# Altium schematic sheet ADC_Top Sheet V2.SchDoc
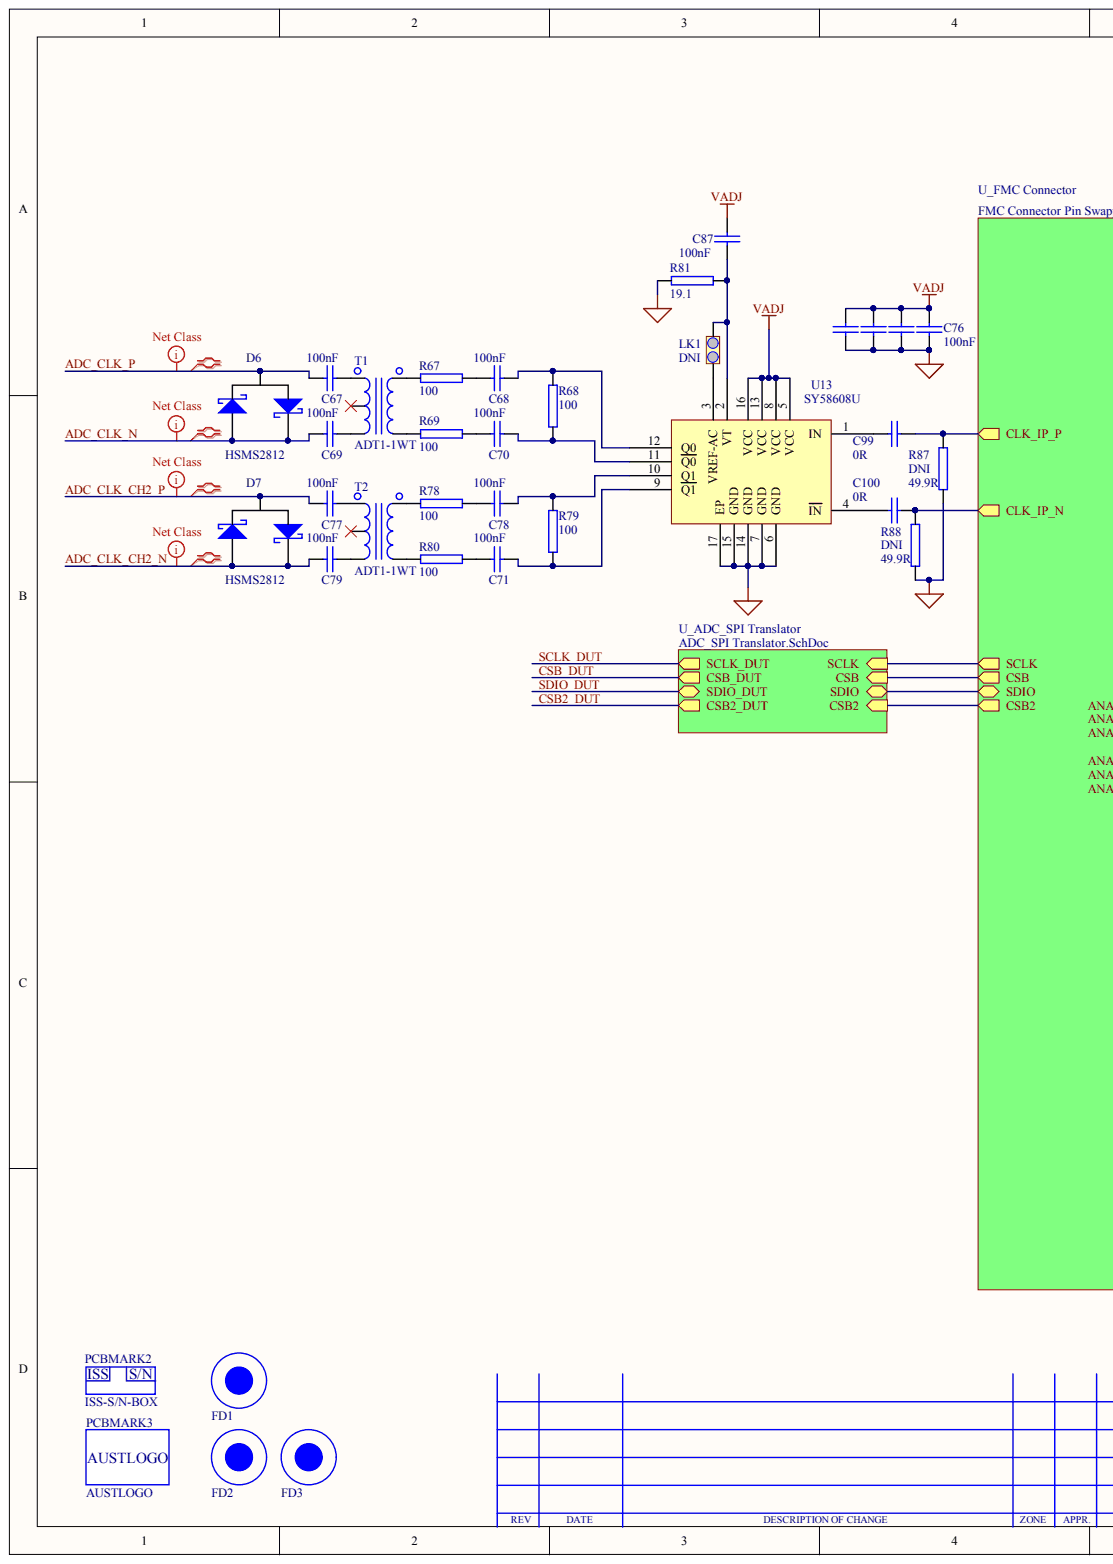
[diagram p0: ADC_Top Sheet V2.SchDoc, left]
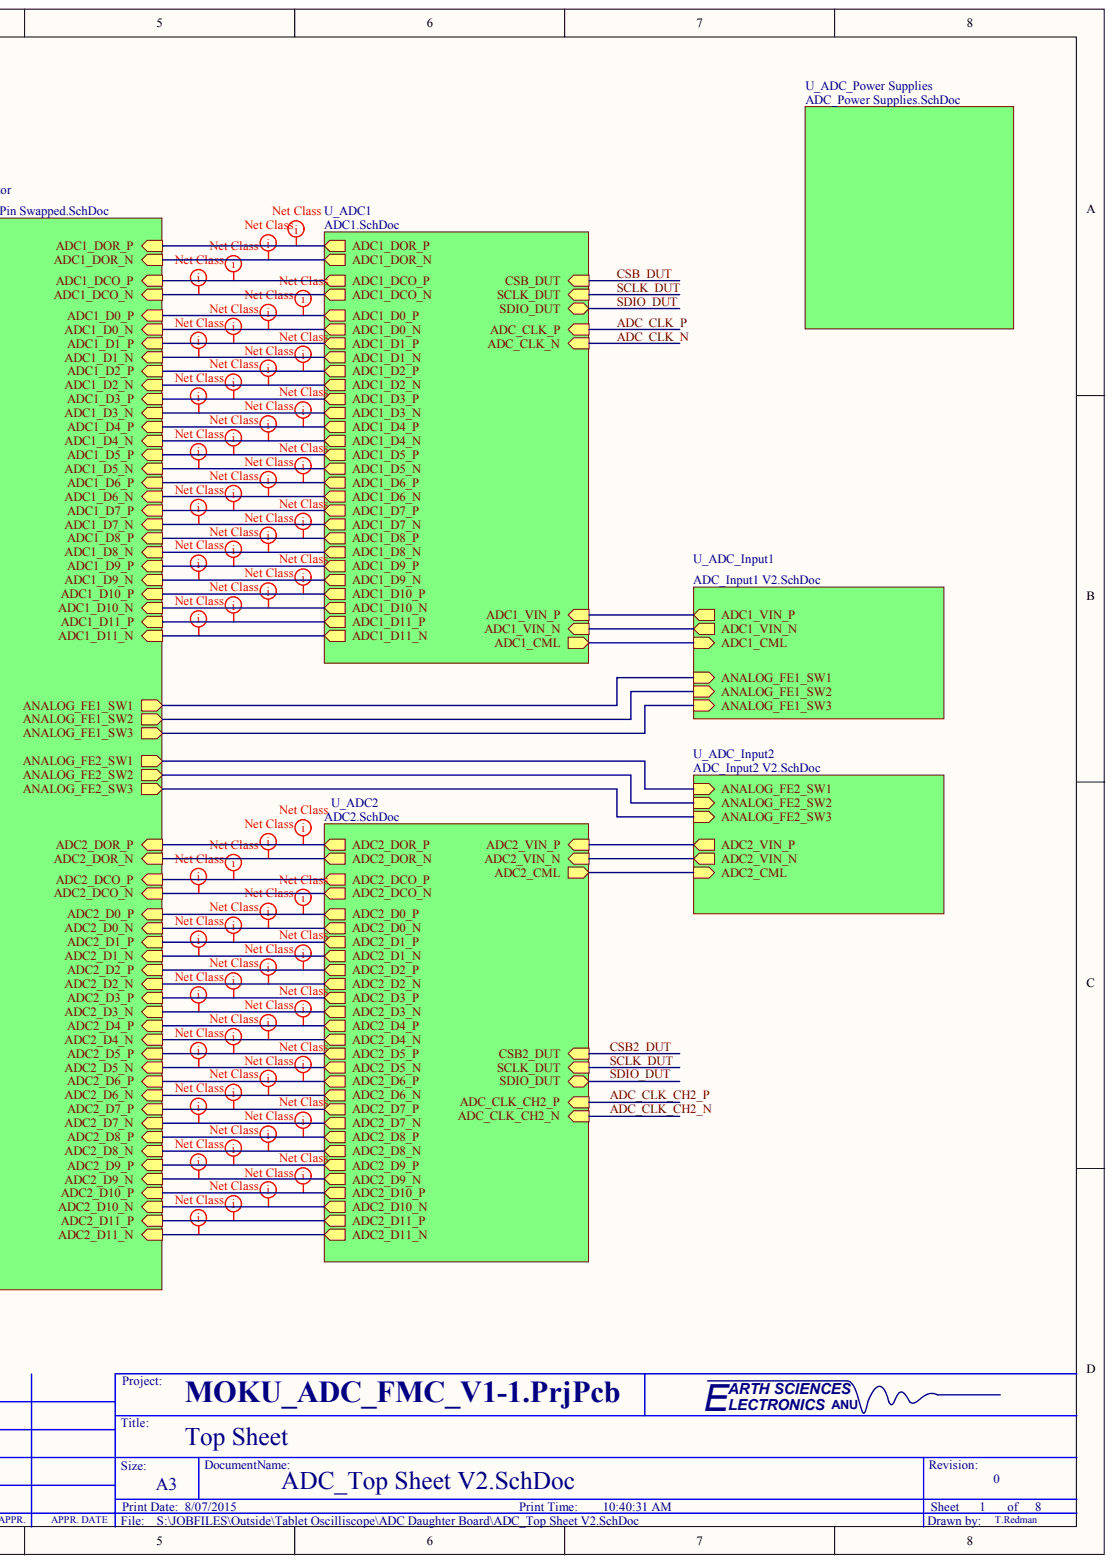
[diagram p1: ADC_Top Sheet V2.SchDoc, right]

## Parts
C67  100nF  [0402_CAP]
C68  100nF  [0402_CAP]
C69  100nF  [0402_CAP]
C70  100nF  [0402_CAP]
C71  100nF  [0402_CAP]
C76  100nF  [0201_CAP]
C77  100nF  [0402_CAP]
C78  100nF  [0402_CAP]
C79  100nF  [0402_CAP]
C87  100nF  [0201_CAP]
C88  100nF  [0201_CAP]  (value not drawn)
C89  100nF  [0201_CAP]  (value not drawn)
C90  100nF  [0201_CAP]
C99  value 0R  [0201_CAP]  (hidden comment: 0R_0201)
C100  value 0R  [0201_CAP]  (hidden comment: 0R_0201)
D6  HSMS2812  [SOT23-3 (0.95pitch)]
D7  HSMS2812  [SOT23-3 (0.95pitch)]
FD1  Fiducial  [FD1]  (value not drawn)
FD2  Fiducial  [FD1]  (value not drawn)
FD3  Fiducial  [FD1]  (value not drawn)
LK1  DNI  [SMD_LNK_SML]
PCBMARK2  ISS-S/N-BOX  [ISS-S/N-BOX-TO]
PCBMARK3  AUSTLOGO  [AUSTLOGO-TO]
R67  100  [0603_Res]
R68  100  [0603_Res]
R69  100  [0603_Res]
R78  100  [0603_Res]
R79  100  [0603_Res]
R80  100  [0603_Res]
R81  19.1  [0603_Res]
R87  DNI  value 49.9R  [0603_Res]
R88  DNI  value 49.9R  [0603_Res]
T1  ADT1-1WT  [ADT1-1WT]
T2  ADT1-1WT  [ADT1-1WT]
U13  SY58608U  [QFN16-3x3 No Via]

## Nets
AGND: C76.1 C88.1 C89.1 C90.1 R81.2 R87.1 R88.1 U13.6(GND) U13.7(GND) U13.14(GND) U13.15(GND) U13.17(EP)
VADJ: C76.2 C87.2 C88.2 C89.2 C90.2 U13.5(VCC) U13.8(VCC) U13.13(VCC) U13.16(VCC)
ADC_CLK_CH2_N: C79.1 D7.1 D7.2
ADC_CLK_CH2_P: C77.1 D7.3
ADC_CLK_N: C69.1 D6.1 D6.2
ADC_CLK_P: C67.1 D6.3
NetC67_2: C67.2 T1.6
NetC68_1: C68.1 R67.1
NetC68_2: C68.2 R68.2 U13.12(Q0)
NetC69_2: C69.2 T1.4
NetC70_1: C70.1 R69.1
NetC70_2: C70.2 R68.1 U13.11(Q0)
NetC71_1: C71.1 R80.1
NetC71_2: C71.2 R79.1 U13.9(Q1)
NetC77_2: C77.2 T2.6
NetC78_1: C78.1 R78.1
NetC78_2: C78.2 R79.2 U13.10(Q1)
NetC79_2: C79.2 T2.4
NetC87_1: C87.1 LK1.2 R81.1 U13.2(VT)
NetC99_1: C99.1 R87.2
NetC99_2: C99.2 U13.1(IN)
NetC100_1: C100.1 R88.2
NetC100_2: C100.2 U13.4(IN)
NetLK1_1: LK1.1 U13.3(VREF-AC)
NetR67_2: R67.2 T1.3
NetR69_2: R69.2 T1.1
NetR78_2: R78.2 T2.3
NetR80_2: R80.2 T2.1
NetT1_2: T1.2
NetT2_2: T2.2
ADC1_CML:
ADC1_D0_N:
ADC1_D0_P:
ADC1_D1_N:
ADC1_D1_P:
ADC1_D2_N:
ADC1_D2_P:
ADC1_D3_N:
ADC1_D3_P:
ADC1_D4_N:
ADC1_D4_P:
ADC1_D5_N:
ADC1_D5_P:
ADC1_D6_N:
ADC1_D6_P:
ADC1_D7_N:
ADC1_D7_P:
ADC1_D8_N:
ADC1_D8_P:
ADC1_D9_N:
ADC1_D9_P:
ADC1_D10_N:
ADC1_D10_P:
ADC1_D11_N:
ADC1_D11_P:
ADC1_DCO_N:
ADC1_DCO_P:
ADC1_DOR_N:
ADC1_DOR_P:
ADC1_VIN_N:
ADC1_VIN_P:
ADC2_CML:
ADC2_D0_N:
ADC2_D0_P:
ADC2_D1_N:
ADC2_D1_P:
ADC2_D2_N:
ADC2_D2_P:
ADC2_D3_N:
ADC2_D3_P:
ADC2_D4_N:
ADC2_D4_P:
ADC2_D5_N:
ADC2_D5_P:
ADC2_D6_N:
ADC2_D6_P:
ADC2_D7_N:
ADC2_D7_P:
ADC2_D8_N:
ADC2_D8_P:
ADC2_D9_N:
ADC2_D9_P:
ADC2_D10_N:
ADC2_D10_P:
ADC2_D11_N:
ADC2_D11_P:
ADC2_DCO_N:
ADC2_DCO_P:
ADC2_DOR_N:
ADC2_DOR_P:
ADC2_VIN_N:
ADC2_VIN_P:
ANALOG_FE1_SW1:
ANALOG_FE1_SW2:
ANALOG_FE1_SW3:
ANALOG_FE2_SW1:
ANALOG_FE2_SW2:
ANALOG_FE2_SW3:
CSB:
CSB2:
CSB2_DUT:
CSB_DUT:
SCLK:
SCLK_DUT:
SDIO:
SDIO_DUT:

## Library data of the parts
C67-C71 C76-C79 C87-C90 C99 C100 = CAP: capacitor, non-polarised; Component Kind: Standard; Library Name: Devices.SCHlib
D6 D7 = Schottky Diode_1: Schottky Diode; Component Kind: Standard; Library Name: Tristan.SchLib
FD1-FD3 = Fiducial 1: Fiducial 2mm outer 0.6 inner r; Component Kind: Standard; Library Name: board markings SCH.SCHlib
LK1 = LINK: Component Kind: Standard; Library Name: Devices.SCHlib
PCBMARK2 = ISS-S/N-BOX: board marking ISS-S/N-BOX; Component Kind: Standard; Library Name: board markings SCH.SCHlib
PCBMARK3 = AUSTLOGO: board marking AUSTLOGO; Component Kind: Standard; Library Name: board markings SCH.SCHlib
R67-R69 R78-R81 R87 R88 = RES2: resistor; Component Kind: Standard; Library Name: Devices.SCHlib
  R81: VALUE: 19.1
U13 = SY58607U: Clock Buffer; Component Kind: Standard; Datasheet: http://www.micrel.com/_PDF/HBW/sy58607u.pdf; Library Name: Tristan.SchLib
T1 T2 = ADT1-1WT: Component Kind: Standard; Description: ADT1-1WT; Library Name: Tristan.SchLib
